# Altium schematic sheet Voltage Sense 2.SchDoc
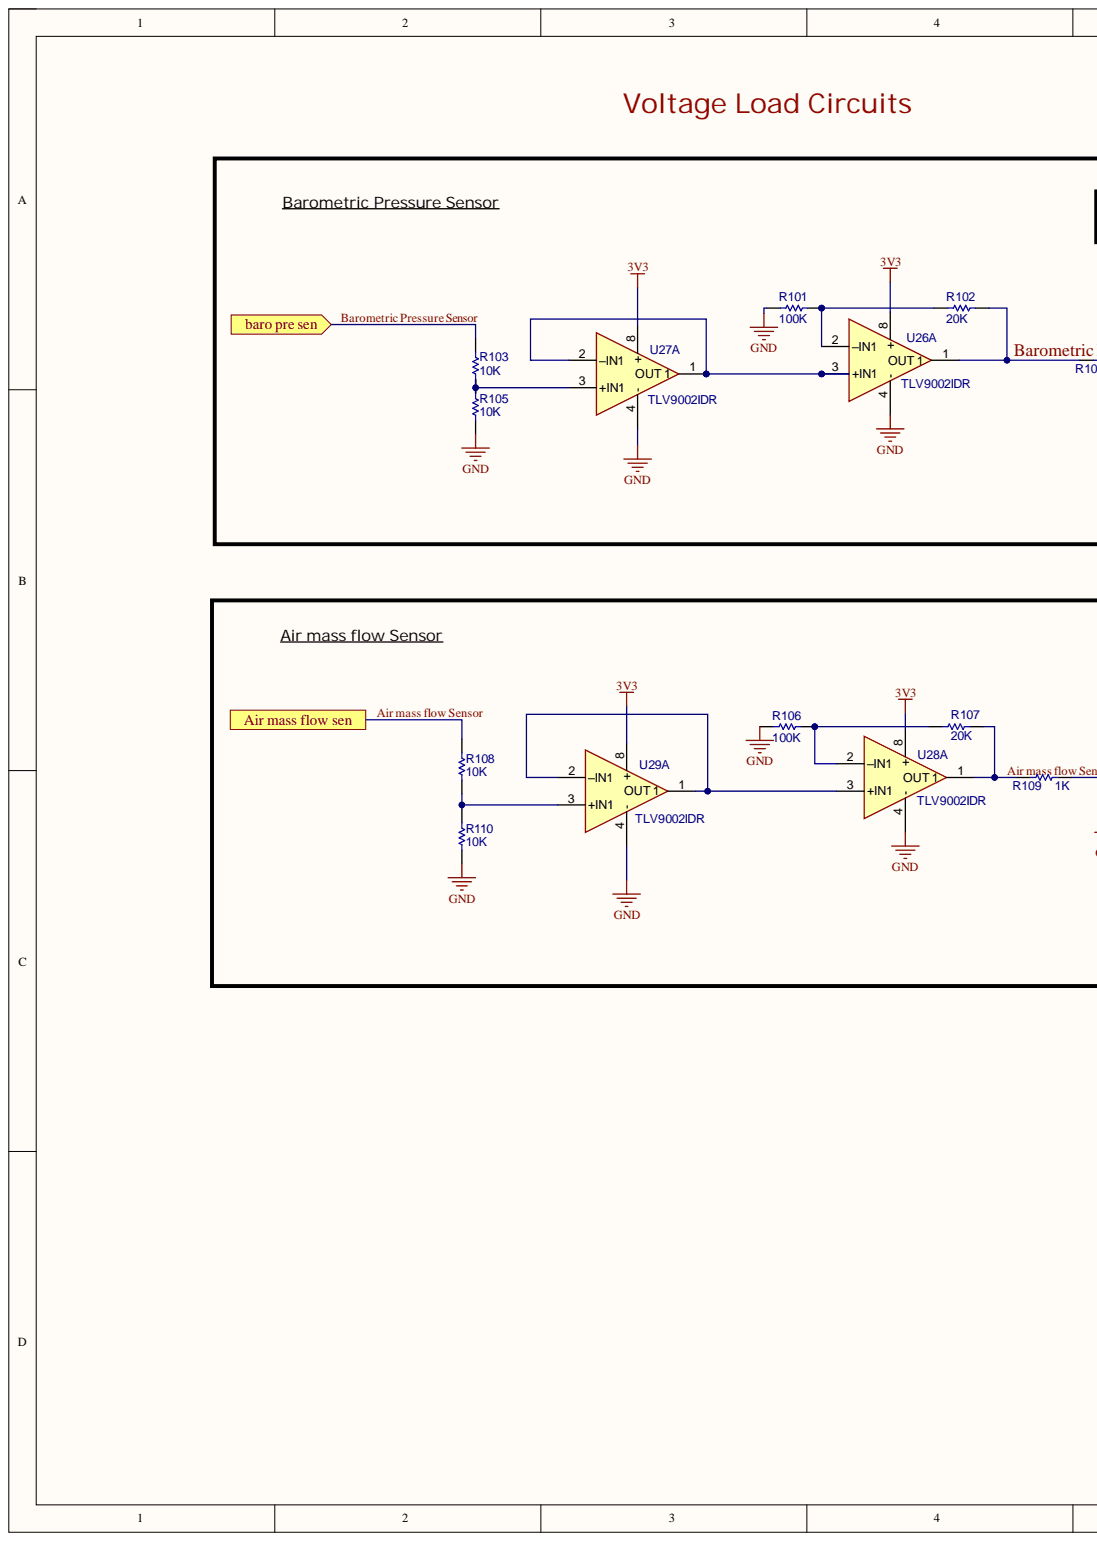
[diagram p0: Voltage Sense 2.SchDoc, left]
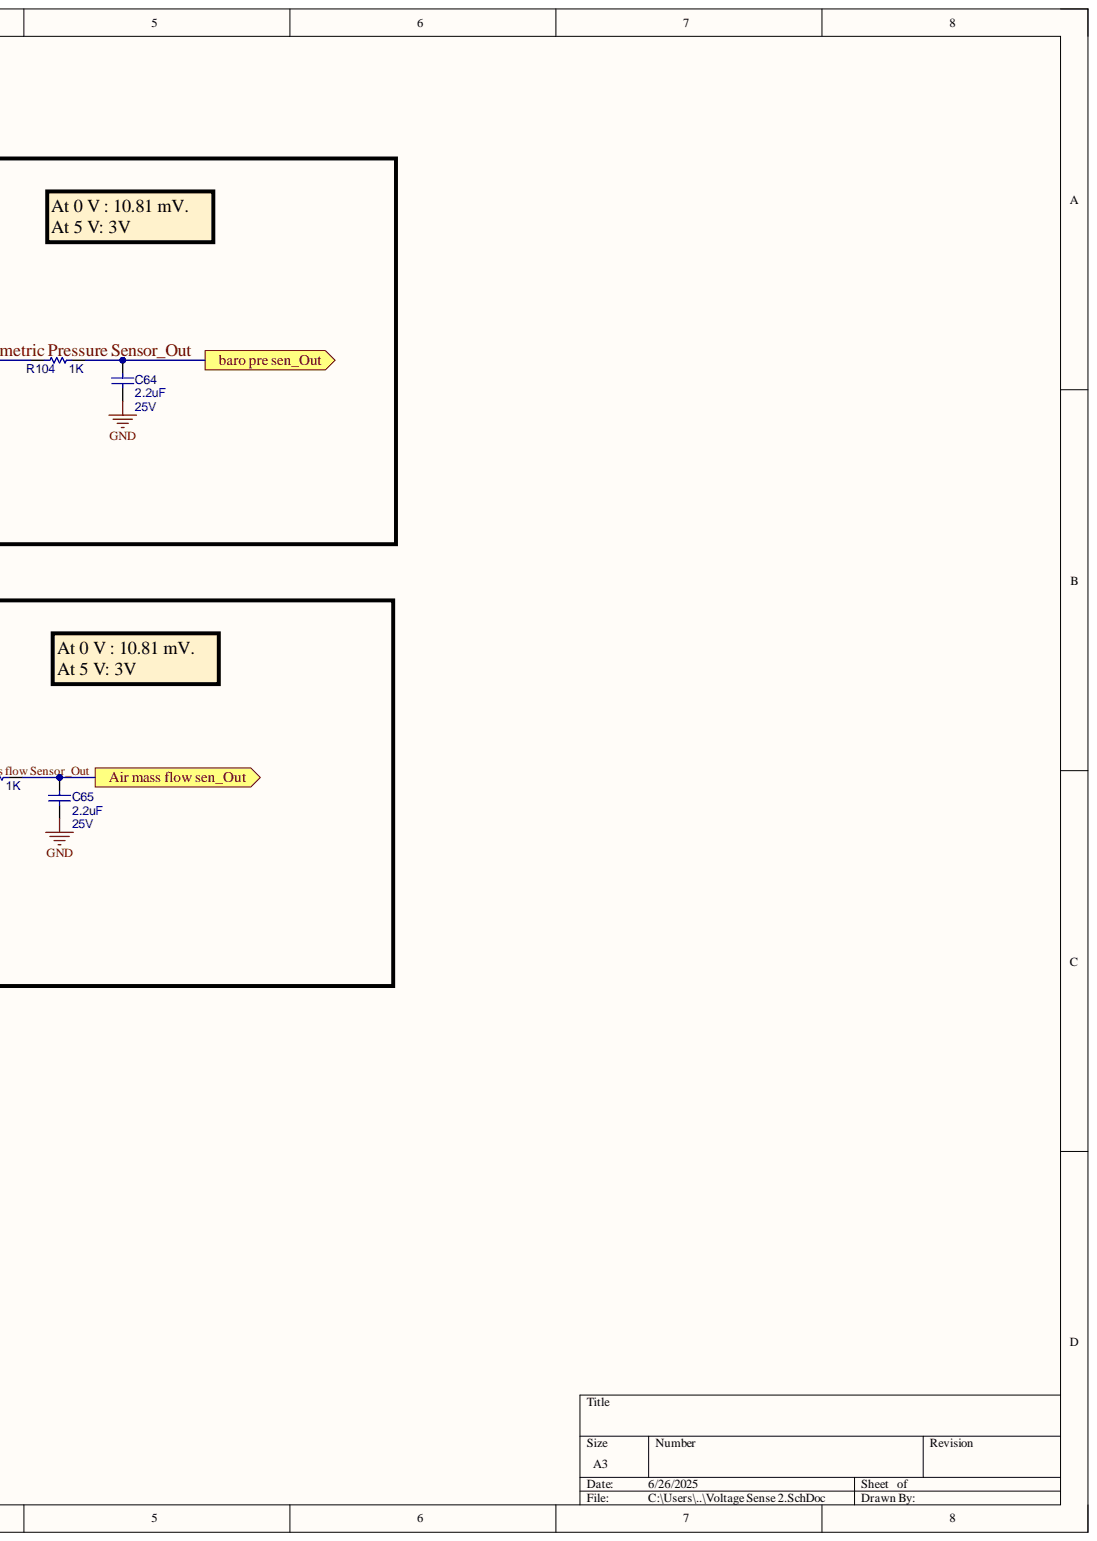
[diagram p1: Voltage Sense 2.SchDoc, right]

## Parts
C64  2.2uF  Voltage: 25V  [CAP-0603]
C65  2.2uF  Voltage: 25V  [CAP-0603]
R101  100k  [RES-0603]
R102  20K  [RES-0603]
R103  10k  [RES-0603]
R104  1K  [RES-0603]
R105  10K  [RES-0603]
R106  100K  [RES-0603]
R107  20K  [RES-0603]
R108  10K  [RES-0603]
R109  1K  [RES-0603]
R110  10K  [RES-0603]
U26  TLV9002IDR  [SOIC127P599X175-8N]  (part A)
U27  TLV9002IDR  [SOIC127P599X175-8N]  (part A)
U28  TLV9002IDR  [SOIC127P599X175-8N]  (part A)
U29  TLV9002IDR  [SOIC127P599X175-8N]  (part A)

## Ports
Air mass flow sen, Air mass flow sen_Out, baro pre sen, baro pre sen_Out

## Nets
3V3: U26.8(+) U27.8(+) U28.8(+) U29.8(+)
GND: C64.2 C65.2 R101.2 R105.1 R106.2 R110.1 U26.4(-) U27.4(-) U28.4(-) U29.4(-)
Air mass flow sen_Out: C65.1 R109.1 ; port Air mass flow sen_Out
Air mass flow Sensor: R108.2 ; port Air mass flow sen
Air mass flow Sensor_Out: R107.2 R109.2 U28.1(OUT_1)
baro pre sen_Out: C64.1 R104.1 ; port baro pre sen_Out
Barometric Pressure Sensor: R103.2 ; port baro pre sen
Barometric Pressure Sensor_Out: R102.2 R104.2 U26.1(OUT_1)
NetR101_1: R101.1 R102.1 U26.2(–IN1)
NetR103_1: R103.1 R105.2 U27.3(+IN1)
NetR106_1: R106.1 R107.1 U28.2(–IN1)
NetR108_1: R108.1 R110.2 U29.3(+IN1)
NetU26_3: U26.3(+IN1) U27.1(OUT_1) U27.2(–IN1)
NetU28_3: U28.3(+IN1) U29.1(OUT_1) U29.2(–IN1)

## Library data of the parts
C64 C65 = CAP: CAP CER 2.2UF 25V X5R 0603; Manufacturer: Taiyo Yuden; Part Number: TMK107ABJ225KA-T; Tolerance: ±1%; Component Kind: Standard; Library Name: Daughter_board_SE3_V1.SCHLIB; Package: 0603; Technology: SMT; Value: 2.2uF
R101 = RES-2: RES SMD 100K OHM 1% 1/10W 0603,MCT06030C1003FP500; Manufacturer: YAGEO; Part Number: AC0603FR-07100KL; Power Rating: 100mW; Component Kind: Standard; Library Name: Daughter_board_SE3_V1.SCHLIB; Package: 0603; Tolerance: ±1%; Value: 100K
R102 R107 = RES-2_28: RES 20K OHM 1% 1/10W 0603; Manufacturer: YAGEO; Part Number: RC0603FR-0720KL; Case/Package: 0603; Component Kind: Standard; Tolerance: ±1%; Value: 20K; Library Name: GENSET CONTROLLER BETA V01.SCHLIB
R103 R105 = RES-2_14: RES SMD 10K OHM 1% 1/10W 0603,CRCW060310K0FKEI; Manufacturer: YAGEO; Part Number: AC0603FR-0710KL; Power Rating: 100mW; Component Kind: Standard; Package: 0603; Tolerance: ±1%; Value: 10K; Library Name: GENSET CONTROLLER BETA V01.SCHLIB
R104 R109 = RES-2: RES SMD 1K OHM 1% 1/10W 0603; Manufacturer: YAGEO; Part Number: AC0603FR-071KL; Power Rating: 100mW; Component Kind: Standard; Library Name: Daughter_board_SE3_V1.SCHLIB; Package: 0603; Tolerance: ±1%; Value: 1K
R106 = RES-2: RES SMD 100K OHM 1% 1/10W 0603; Manufacturer: YAGEO; Part Number: AC0603FR-07100KL; Power Rating: 100mW; Component Kind: Standard; Library Name: Daughter_board_SE3_V1.SCHLIB; Package: 0603; Tolerance: ±1%; Value: 100K
R108 R110 = RES-2_14: RES SMD 10K OHM 1% 1/10W 0603; Manufacturer: YAGEO; Part Number: AC0603FR-0710KL; Power Rating: 100mW; Component Kind: Standard; Package: 0603; Tolerance: ±1%; Value: 10K; Library Name: GENSET CONTROLLER BETA V01.SCHLIB
U26-U29 = TLV9002IDR: IC OPAMP GP 2 CIRCUIT 8SOIC; Manufacturer: Texas Instruments; Component Kind: Standard; Part Number: TLV9002IDR; Library Name: GENSET CONTROLLER BETA V1.SCHLIB
